# Altium schematic sheet [08] - HDMI.SchDoc("[08] - HDMI")
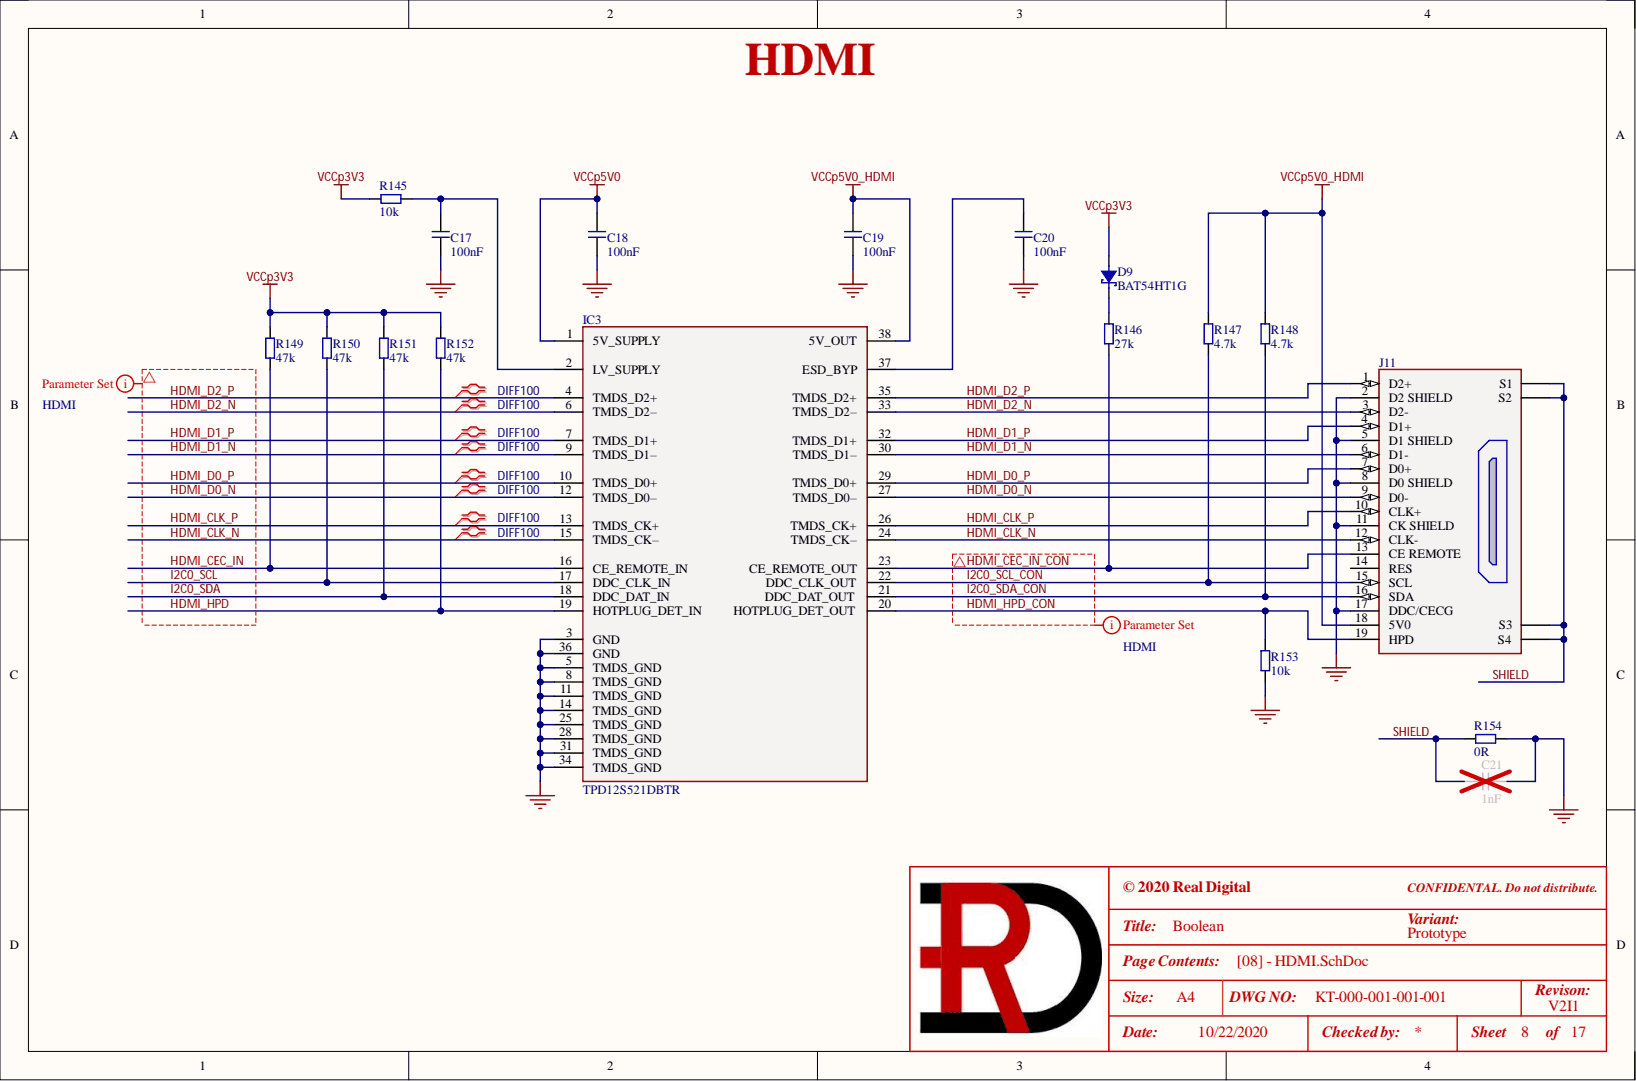
[diagram p0: [08] - HDMI.SchDoc]

## Parts
C17  100nF  [C0402]
C18  100nF  [C0402]
C19  100nF  [C0402]
C20  100nF  [C0402]
C21  1nF  [C0805]
D9  BAT54HT1G  [SOD-323_ONSEMI]
IC3  TPD12S521DBTR  [TFSOP-38_TI_DBT0038A]
J11  10029449-111RLF  [10029449-111RLF]  (value not drawn)
R145  10k  [R0402]
R146  27k  [R0402]
R147  4.7k  [R0402]
R148  4.7k  [R0402]
R149  47k  [R0402]
R150  47k  [R0402]
R151  47k  [R0402]
R152  47k  [R0402]
R153  10k  [R0402]
R154  0R  [R0805]

## Nets
GND: C17.1 C18.1 C19.1 C20.1 C21.2 IC3.3(GND) IC3.5(TMDS_GND) IC3.8(TMDS_GND) IC3.11(TMDS_GND) IC3.14(TMDS_GND) IC3.25(TMDS_GND) IC3.28(TMDS_GND) IC3.31(TMDS_GND) IC3.34(TMDS_GND) IC3.36(GND) J11.2(D2_SHIELD) J11.5(D1_SHIELD) J11.8(D0_SHIELD) J11.11(CK_SHIELD) J11.17(DDC/CECG) R153.1 R154.2
VCCp3V3: D9.A R145.1 R149.2 R150.2 R151.2 R152.2
VCCp5V0: C18.2 IC3.1(5V_SUPPLY)
VCCp5V0_HDMI: C19.2 IC3.38(5V_OUT) J11.18(5V0) R147.2 R148.2
HDMI_CEC_IN: IC3.16(CE_REMOTE_IN) R149.1
HDMI_CEC_IN_CON: IC3.23(CE_REMOTE_OUT) J11.13(CE_REMOTE) R146.1
HDMI_CLK_N: IC3.15(TMDS_CK–) IC3.24(TMDS_CK–) J11.12(CLK-)
HDMI_CLK_P: IC3.13(TMDS_CK+) IC3.26(TMDS_CK+) J11.10(CLK+)
HDMI_D0_N: IC3.12(TMDS_D0–) IC3.27(TMDS_D0–) J11.9(D0-)
HDMI_D0_P: IC3.10(TMDS_D0+) IC3.29(TMDS_D0+) J11.7(D0+)
HDMI_D1_N: IC3.9(TMDS_D1–) IC3.30(TMDS_D1–) J11.6(D1-)
HDMI_D1_P: IC3.7(TMDS_D1+) IC3.32(TMDS_D1+) J11.4(D1+)
HDMI_D2_N: IC3.6(TMDS_D2–) IC3.33(TMDS_D2–) J11.3(D2-)
HDMI_D2_P: IC3.4(TMDS_D2+) IC3.35(TMDS_D2+) J11.1(D2+)
HDMI_HPD: IC3.19(HOTPLUG_DET_IN) R152.1
HDMI_HPD_CON: IC3.20(HOTPLUG_DET_OUT) J11.19(HPD) R153.2
I2C0_SCL: IC3.17(DDC_CLK_IN) R150.1
I2C0_SCL_CON: IC3.22(DDC_CLK_OUT) J11.15(SCL) R147.1
I2C0_SDA: IC3.18(DDC_DAT_IN) R151.1
I2C0_SDA_CON: IC3.21(DDC_DAT_OUT) J11.16(SDA) R148.1
SHIELD: C21.1 J11.S1 J11.S2 J11.S3 J11.S4 R154.1
NetC17_2: C17.2 IC3.2(LV_SUPPLY) R145.2
NetC20_2: C20.2 IC3.37(ESD_BYP)
NetD9_K: D9.K R146.2

Not connected: J11 14(RES)

## Library data of the parts
C17-C20 = GRM155R71E104KE14J: CAP CER 0.1uF 25V X7R 0402; Manufacturer 1: Murata Electronics; .Checked_PCB: AC; .Creator: RV; C P/N: RD-111-0006; LoadStatus: Load; Tolerance: 10%; Category: Capacitors; Component Kind: Standard; Library Name: KT-RealDigital.IntLib; Manufacturer 1 Part Number: GRM155R71E104KE14J; Temperature Coefficient: X7R; Voltage - Rated: 25V; .Checked_SCH: AC
C21 = GCJ21AR72E102KXJ1D: CAP CER 1nF 250V X7R 0805; Manufacturer 1: Murata Electronics; .Creator: RV; LoadStatus: Load; Tolerance: ±10%; Component Kind: Standard; Library Name: KT-RealDigital.IntLib; Manufacturer 1 Part Number: GCJ21AR72E102KXJ1D; Temperature Coefficient: X7R; Voltage - Rated: 250V
D9 = BAT54HT1G: DIODE SCHOTTKY 30V 200MA SOD323; Manufacturer 1: ON Semiconductor; .Checked_PCB: AC; .Creator: RV; C P/N: 121-0304; LoadStatus: Load; Category: Diodes; Component Kind: Standard; Library Name: KT-RealDigital.IntLib; Manufacturer 1 Part Number: BAT54HT1G; .Checked_SCH: AC
IC3 = TPD12S521DBTR: Specialised HDMI RECEIVER PORT PROTECTION; Manufacturer 1: Texas Instruments; .Checked_PCB: AC; .Creator: RV; C P/N: RD-126-0002; LoadStatus: Load; Category: IC; Component Kind: Standard; Library Name: KT-RealDigital.IntLib; Manufacturer 1 Part Number: TPD12S521DBTR; .Checked_SCH: AC
J11 = 10029449-111RLF: CONN RCPT 1.4 HDMI 19POS SMD R/A; Manufacturer 1: Amphenol ICC (FCI); .Checked_PCB: AC; .Creator: RV; C P/N: RD-161-0001; LoadStatus: Load; Category: Connectors; Component Kind: Standard; Library Name: KT-RealDigital.IntLib; Manufacturer 1 Part Number: 10029449-111RLF; .Checked_SCH: AC
R145 R153 = RC0402JR-0710KL: RES SMD 10K OHM 5% 1/16W 0402; Manufacturer 1: Yageo; .Checked_PCB: AC; .Creator: RV; C P/N: 101-0047; LoadStatus: Load; Category: Resistors; Component Kind: Standard; Library Name: KT-RealDigital.IntLib; Manufacturer 1 Part Number: RC0402JR-0710KL; Tolerance: 5%; .Checked_SCH: AC
R146 = RC0402JR-0727KL: RES SMD 27K OHM 5% 1/16W 0402; Manufacturer 1: Yageo; .Checked_PCB: AC; .Creator: RV; C P/N: 101-0802; LoadStatus: Load; Category: Resistors; Component Kind: Standard; Library Name: KT-RealDigital.IntLib; Manufacturer 1 Part Number: RC0402JR-0727KL; Tolerance: 5%; .Checked_SCH: AC
R147 R148 = RC0402FR-074K7P: RES SMD 4.7K OHM 1% 1/16W 0402; Manufacturer 1: Yageo; .Checked_PCB: AC; .Creator: RV; C P/N: 101-0129; LoadStatus: Load; Category: Resistors; Component Kind: Standard; Library Name: KT-RealDigital.IntLib; Manufacturer 1 Part Number: RC0402FR-074K7P; Tolerance: 1%; .Checked_SCH: AC
R149-R152 = RC0402JR-0747KL: RES SMD 47K OHM 5% 1/16W 0402; Manufacturer 1: Yageo; .Checked_PCB: AC; .Creator: RV; C P/N: 101-0074; LoadStatus: Load; Category: Resistors; Component Kind: Standard; Library Name: KT-RealDigital.IntLib; Manufacturer 1 Part Number: RC0402JR-0747KL; Tolerance: 5%; .Checked_SCH: AC
R154 = RC0805JR-070RL: RES SMD 0 OHM JUMPER 1/8W 0805; Manufacturer 1: Yageo; .Checked_PCB: AC; .Creator: RV; LoadStatus: Load; Category: Resistors; Component Kind: Standard; Library Name: KT-RealDigital.IntLib; Manufacturer 1 Part Number: RC0805JR-070RL; Tolerance: Jumper; .Checked_SCH: AC
